# Altium schematic sheet Power.SchDoc
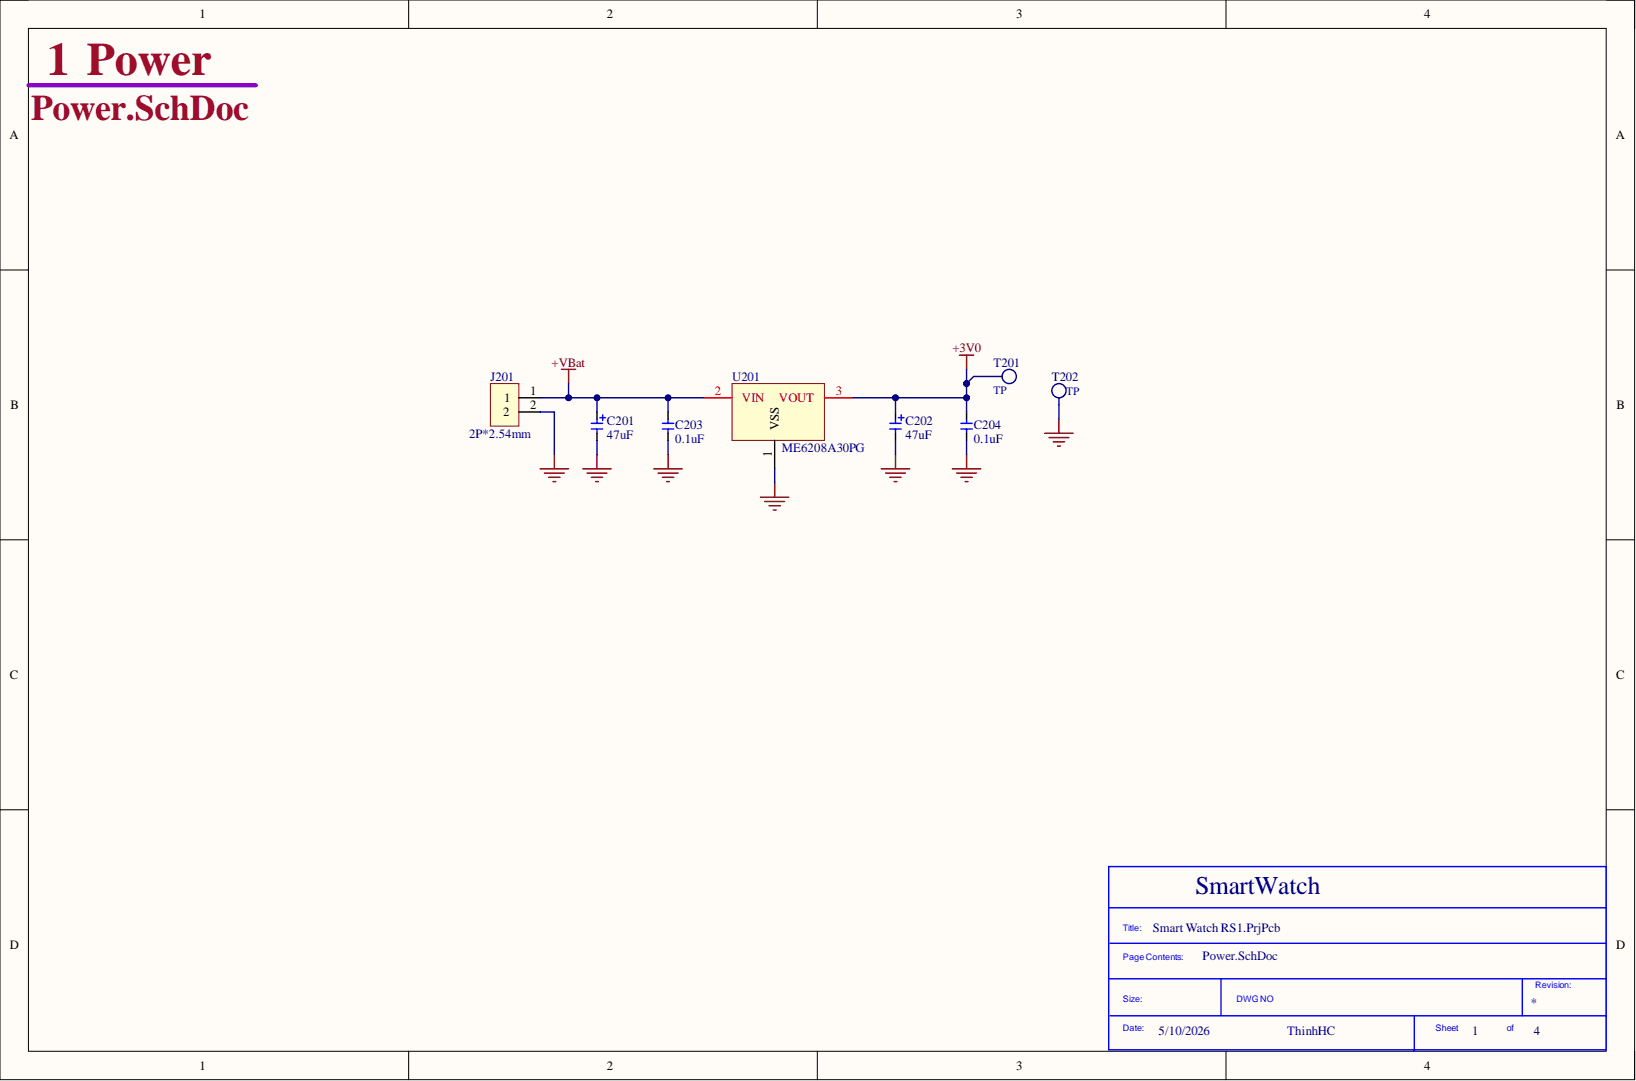
[diagram p0: Power.SchDoc]

## Parts
C201  47uF  [CASE-B_3528]
C202  47uF  [CASE-B_3528]
C203  0.1uF  [C_0402]
C204  0.1uF  [C_0402]
J201  2P*2.54mm  [2P*2.54mm]  (no BOM)
T201  TP  [TP-D45MIL]  (no BOM)
T202  TP  [TP-D45MIL]  (no BOM)
U201  ME6208A30PG  [SOT_89]

## Nets
+3V0: C202.1 C204.2 T201.1 U201.3
+VBat: C201.1 C203.2 J201.1 U201.2
GND: C201.2 C202.2 C203.1 C204.1 J201.2 T202.1 U201.1

## Library data of the parts
C201 C202 = CA45-B-10V-47uF-K: 47uF 10V -55℃~+125℃ 2.4Ω@100kHz ±10% CASE-B-3528-19(mm) Tantalum Capacitors ROHS; Manufacturer: CEC(Shenzhen Zhenhua XinYun Elec); Assembly Type: SMT Assembly; Component Kind: Standard; ComponentLink1Description: Digikey Link; ComponentLink1URL: https://www.digikey.com/en/products/detail/yageo/CC0603KRX7R9BB104/2103082?s=N4IgTCBcDaIMwEYEFoFwCzuWZA5AIiALoC%2BQA; ComponentLink2Description: LCSC Link; ComponentLink2URL: https://jlcpcb.com/parts/componentSearch?searchTxt=10k%200603; LCSC Part Number: C136658; Manufacturer Part Number: CA45-B-10V-47uF-K; Package: CASE-B-3528-19(mm); Library Name: HomeMade SmartWatch.SchLib
C203 C204 = CL05B104KO5NNNC: 100nF 0402 16V X7R ±10% Multilayer Ceramic Capacitors MLCC - SMD/SMT ROHS; Manufacturer: Samsung Electro-Mechanics; Assembly Type: SMT Assembly; Component Kind: Standard; ComponentLink1Description: Digikey Link; ComponentLink1URL: https://www.digikey.com/en/products/detail/yageo/CC0603KRX7R9BB104/2103082?s=N4IgTCBcDaIMwEYEFoFwCzuWZA5AIiALoC%2BQA; ComponentLink2Description: LCSC Link; ComponentLink2URL: https://jlcpcb.com/parts/componentSearch?searchTxt=10k%200603; LCSC Part Number: C1525; Manufacturer Part Number: CL05B104KO5NNNC; Package: 0402; Library Name: HomeMade SmartWatch.SchLib
T201 T202 = TEST-POINT'4545': Manufacturer: No; Description: TEST-POINT'4545'; LCSC Part Number: No; Library Name: Smart Watch RS1.SchLib; Manufacturer Part Number: No; Package: No
U201 = ME6208A30PG: -45℃~+150℃ 1 150mA 18V 3V 3uA Fixed Positive SOT-89 Voltage Regulators - Linear, Low Drop Out (LDO) Regulators ROHS; Manufacturer: MICRONE(Nanjing Micro One Elec); Assembly Type: SMT Assembly; Component Kind: Standard; ComponentLink1Description: Digikey Link; ComponentLink1URL: https://www.digikey.com/en/products/detail/yageo/CC0603KRX7R9BB104/2103082?s=N4IgTCBcDaIMwEYEFoFwCzuWZA5AIiALoC%2BQA; ComponentLink2Description: LCSC Link; ComponentLink2URL: https://jlcpcb.com/parts/componentSearch?searchTxt=10k%200603; LCSC Part Number: C80196; Manufacturer Part Number: ME6208A30PG; Package: SOT-89; Library Name: HomeMade SmartWatch.SchLib
J201 = 2P*2.54mm: Assembly Type: Handing Solder; ComponentLink1Description: Digikey Link; ComponentLink1URL: https://www.digikey.com/en/products/detail/yageo/CC0603KRX7R9BB104/2103082?s=N4IgTCBcDaIMwEYEFoFwCzuWZA5AIiALoC%2BQA; ComponentLink2Description: LCSC Link; ComponentLink2URL: https://jlcpcb.com/parts/componentSearch?searchTxt=10k%200603; Description: 2P*2.54mm; LCSC Part Number: -; Manufacturer: -; Manufacturer Part Number: 2P*2.54mm; Package: -; Library Name: HomeMade SmartWatch.SchLib
